# Altium schematic sheet Sheet1.SchDoc(Sheet1)
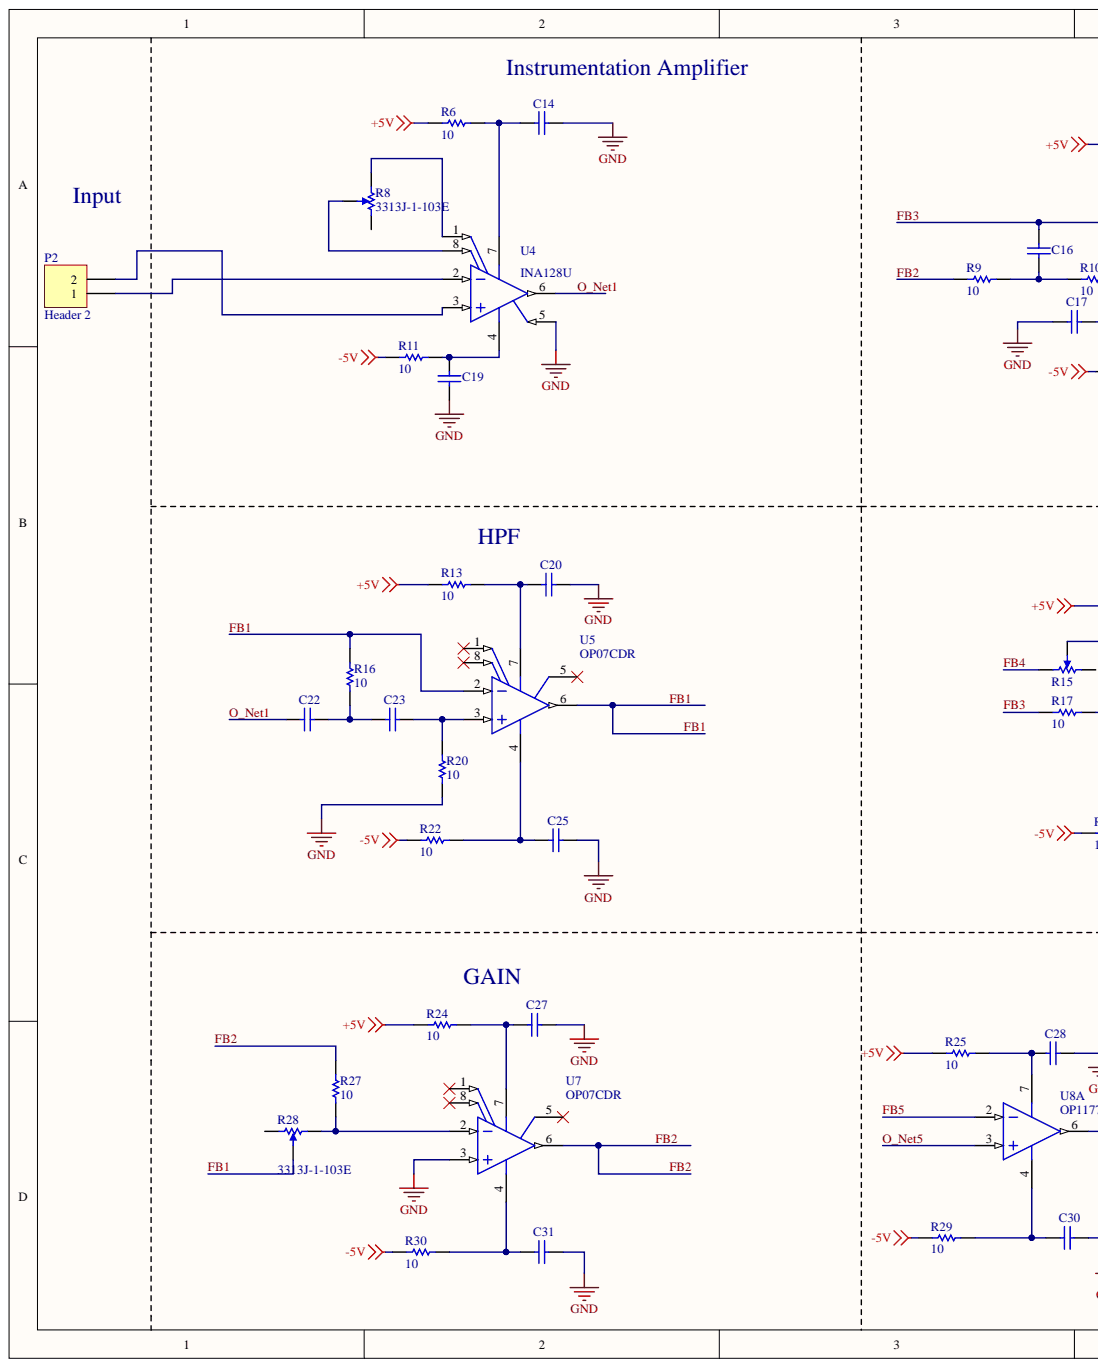
[diagram p0: Sheet1.SchDoc, left]
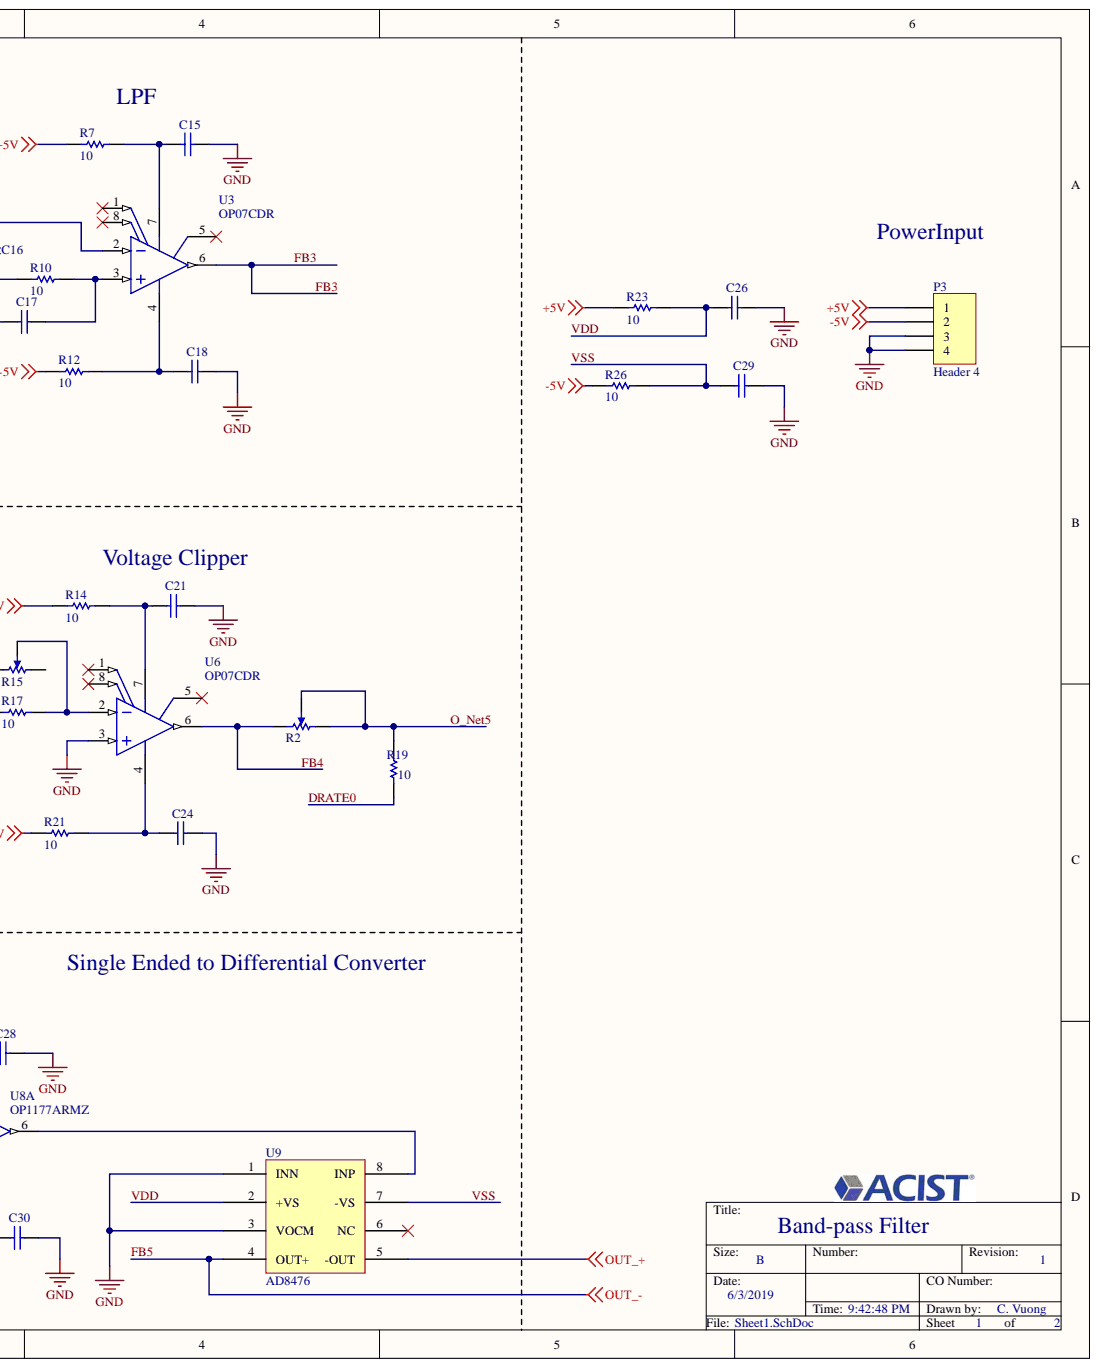
[diagram p1: Sheet1.SchDoc, right]

## Parts
C14  C1608X5R1A106K080AC  [CAPC1608X90X20ML10]  (value not drawn)
C15  C1608X5R1A106K080AC  [CAPC1608X90X20ML10]  (value not drawn)
C16  C1608X5R1A106K080AC  [CAPC1608X90X20ML10]  (value not drawn)
C17  C1608X5R1A106K080AC  [CAPC1608X90X20ML10]  (value not drawn)
C18  C1608X5R1A106K080AC  [CAPC1608X90X20ML10]  (value not drawn)
C19  C1608X5R1A106K080AC  [CAPC1608X90X20ML10]  (value not drawn)
C20  C1608X5R1A106K080AC  [CAPC1608X90X20ML10]  (value not drawn)
C21  C1608X5R1A106K080AC  [CAPC1608X90X20ML10]  (value not drawn)
C22  C1608X5R1A106K080AC  [CAPC1608X90X20ML10]  (value not drawn)
C23  C1608X5R1A106K080AC  [CAPC1608X90X20ML10]  (value not drawn)
C24  C1608X5R1A106K080AC  [CAPC1608X90X20ML10]  (value not drawn)
C25  C1608X5R1A106K080AC  [CAPC1608X90X20ML10]  (value not drawn)
C26  C1608X5R1A106K080AC  [CAPC1608X90X20ML10]  (value not drawn)
C27  C1608X5R1A106K080AC  [CAPC1608X90X20ML10]  (value not drawn)
C28  C1608X5R1A106K080AC  [CAPC1608X90X20ML10]  (value not drawn)
C29  C1608X5R1A106K080AC  [CAPC1608X90X20ML10]  (value not drawn)
C30  C1608X5R1A106K080AC  [CAPC1608X90X20ML10]  (value not drawn)
C31  C1608X5R1A106K080AC  [CAPC1608X90X20ML10]  (value not drawn)
P2  Header 2  [HDR1X2]
P3  Header 4  [HDR1X4]
R2  3313J-1-103E  [BOUR-3313J-1_V]
R6  10  [RESC1608X50X30LL20T20]
R7  10  [RESC1608X50X30LL20T20]
R8  3313J-1-103E  [BOUR-3313J-1_V]
R9  10  [RESC1608X50X30LL20T20]
R10  10  [RESC1608X50X30LL20T20]
R11  10  [RESC1608X50X30LL20T20]
R12  10  [RESC1608X50X30LL20T20]
R13  10  [RESC1608X50X30LL20T20]
R14  10  [RESC1608X50X30LL20T20]
R15  3313J-1-103E  [BOUR-3313J-1_V]
R16  10  [RESC1608X50X30LL20T20]
R17  10  [RESC1608X50X30LL20T20]
R19  10  [RESC1608X50X30LL20T20]
R20  10  [RESC1608X50X30LL20T20]
R21  10  [RESC1608X50X30LL20T20]
R22  10  [RESC1608X50X30LL20T20]
R23  10  [RESC1608X50X30LL20T20]
R24  10  [RESC1608X50X30LL20T20]
R25  10  [RESC1608X50X30LL20T20]
R26  10  [RESC1608X50X30LL20T20]
R27  10  [RESC1608X50X30LL20T20]
R28  3313J-1-103E  [BOUR-3313J-1_V]
R29  10  [RESC1608X50X30LL20T20]
R30  10  [RESC1608X50X30LL20T20]
U3  OP07CDR  [D0008A_M]
U4  INA128U  [D0008A_N]
U5  OP07CDR  [D0008A_M]
U6  OP07CDR  [D0008A_M]
U7  OP07CDR  [D0008A_M]
U8  OP1177ARMZ  [ADI-RM-8_M]  (part A)
U9  AD8476  [MSOP8]

## Nets
+5V: P3.1 R6.2 R7.2 R13.2 R14.2 R23.2 R24.2 R25.2
-5V: P3.2 R11.2 R12.2 R21.2 R22.2 R26.2 R29.2 R30.2
GND: C14.1 C15.1 C17.1 C18.1 C19.1 C20.1 C21.1 C24.1 C25.1 C26.1 C27.1 C28.1 C29.1 C30.1 C31.1 P3.3 P3.4 R20.2 U4.5 U6.3 U7.3 U9.1(INN) U9.3(VOCM)
OUT_+: U9.5(-OUT)
FB1: R16.1 R28.2 U5.2 U5.6
FB2: R9.2 R27.1 U7.6
FB3: C16.1 R17.2 U3.2 U3.6
FB4: R2.3 R15.3 U6.6
FB5: U8.2 U9.4(OUT+)
O_Net1: C22.2 U4.6
O_Net5: R2.1 R2.2 R19.1 U8.3
VDD: C26.2 R23.1 U9.2(+VS)
VDD_D: R19.2
VSS: C29.2 R26.1 U9.7(-VS)
NetC14_2: C14.2 R6.1 U4.7
NetC15_2: C15.2 R7.1 U3.7
NetC16_2: C16.2 R9.1 R10.2
NetC17_2: C17.2 R10.1 U3.3
NetC18_2: C18.2 R12.1 U3.4
NetC19_2: C19.2 R11.1 U4.4
NetC20_2: C20.2 R13.1 U5.7
NetC21_2: C21.2 R14.1 U6.7
NetC22_1: C22.1 C23.2 R16.2
NetC23_1: C23.1 R20.1 U5.3
NetC24_2: C24.2 R21.1 U6.4
NetC25_2: C25.2 R22.1 U5.4
NetC27_2: C27.2 R24.1 U7.7
NetC28_2: C28.2 R25.1 U8.7
NetC30_2: C30.2 R29.1 U8.4
NetC31_2: C31.2 R30.1 U7.4
NetP2_1: P2.1 U4.2
NetP2_2: P2.2 U4.3
NetR8_1: R8.1 U4.1
NetR8_2: R8.2 U4.8
NetR15_2: R15.2 R17.1 U6.2
NetR27_2: R27.2 R28.3 U7.2
NetU8_6: U8.6 U9.8(INP)

Not connected: R8 3; R15 1; R28 1; U3 1 5 8; U5 1 5 8; U6 1 5 8; U7 1 5 8; U9 6(NC)

## Library data of the parts
C14-C31 = CMP-2000-04979-1: Chip Capacitor, 10 uF, +/- 10%, 10 V, -55 to 85 degC, 0603 (1608 Metric), RoHS, Tape and Reel; PCN Part Number: MLCCPartNumberChange30/Nov/2012; Manufacturer: TDK; Voltage - Rated: 10V; Temperature Coefficient: X5R; Product Training Modules: CSeriesGeneralApplicationsSEAT,CCV,andTVCLDesignToolsGuidetoReplacinganElectrolyticCapacitorwithanMLCC; Other Names: 445-7486-1; Online Catalog: CSeries,GeneralPurpose; Kits: 445-9003-KIT-ND-KITCAPCERHICAPMLCCSMD; Design Resources: 20WNon-IsolatedBuckLEDDriverUsingPLLNK460KG; Capacitance: 10µF; Tolerance: ±10%; Thickness (Max): 0.035"(0.90mm); Standard Package: 1; Size / Dimension: 0.063"Lx0.031"W(1.60mmx0.80mm); Series: C; RoHS Information: RoHSCertificate-MLCC; Ratings: -; Packaging: CutTape(CT); Package Reference: 603; Package Description: 2-Pin Surface Mount Device, Body 1.6 x 0.8 mm; Package / Case: 0603(1608Metric); Operating Temperature: -55degC~85degC; Mounting Type: SurfaceMount,MLCC; Manufacturer URL: http://www.tdk.com/; Library Name: Altium Content Vault; Lead Style: -; Lead Spacing: -; Height - Seated (Max): -; Features: -; Family: CeramicCapacitors; Datasheet Version: Mar-15; Datasheet URL: http://download.siliconexpert.com/pdfs/2015/4/21/15/54/45/779/tdk_/manual/37024850441143360mlcc_commercial_general_en.pdf; Component Kind: Standard; Category: Capacitors; Applications: GeneralPurpose
P2 = Header 2: Header, 2-Pin; Signal Integrity: Connector; Library Name: Miscellaneous Connectors.IntLib; LatestRevisionNote: Re-released for DXP Platform.; LatestRevisionDate: 17-Jul-2002; Component Kind: Standard; Publisher: Altium Limited
P3 = Header 4: Header, 4-Pin; Signal Integrity: Connector; Library Name: Miscellaneous Connectors.IntLib; LatestRevisionNote: Re-released for DXP Platform.; LatestRevisionDate: 17-Jul-2002; Component Kind: Standard; Publisher: Altium Limited
R2 R8 R15 R28 = CMP-2000-05585-1: Trimming Potentiometer, 10 KOhm, -55 to 125 degC, 3-Pin SMD, RoHS, Tape and Reel; Manufacturer: Bourns; Track Resistance: 10kohm; Temperature Coefficient: ±100ppm/°C; SVHC: NoSVHC(16-Jun-2014); Resistance Tolerance: ±20%; Power Rating: 125mW; No. of Turns: 1; RoHS Compliant: Yes; Potentiometer Mounting: SMD; Package Reference: 3313J-1; Package Description: 3-Pin Surface Mount Device, Body 3.5 x 3.2 mm, Pitch 1.15 mm; Mounting Technology: SurfaceMount; Manufacturer URL: http://www.bourns.com/; Library Name: Altium Content Vault; Datasheet Version: 05/2016; Datasheet URL: http://www.bourns.com/docs/Product-Datasheets/3313.pdf; Component Kind: Standard
R6 R7 R9-R14 R16 R17 R19-R27 R29 R30 = CMP-2000-02559-1: Part Number: RR0816P-241-D; Manufacturer: Susumu; Library Name: Altium Content Vault; Component Kind: Standard
U3 U5-U7 = CMP-0015-00070-2: Low-Offset Voltage Operational Amplifier, 6 to 36 V, 0 to 70 degC, 8-pin SOIC (D8), Green (RoHS & no Sb/Br); Manufacturer: Texas Instruments; Vn at 1kHz(Typ)(nV/rtHz): 9.8; Vio (25C)(Max)(mV): 0.15; Total Supply Voltage(Min)(+5V is 5, +/-5V is 10): 6; Total Supply Voltage(Max)(+5V is 5, +/-5V is 10): 36; Sub Family: General Purpose Amplifier, High Supply Voltage Amplifier (>=30V), Low Noise Amp (<=10nV/rtHz), Low Offset Voltage Amplifier (<=500uV); Slew Rate(Typ)(V/us): 0.3; Rating: Catalog; Offset Drift(Typ)(uV/C): 0.5; Number of Channels: 1; Iq per channel(Max)(mA): 5; IIB(Max)(pA): 7000; GBW(Typ)(MHz): 0.6; CMRR(Min)(dB): 100; PartNumber: OP07CDR; PackageVersion: 4040047-3/M, 06/2011; PackageReference: D0008A; PackageDescription: 8-Pin Plastic Small Outline, Body 4.9 x 3.9 mm, Pitch 1.27 mm; Mounting Technology: Surface Mount; Library Name: Altium Content Vault; Eco-Plan: Green (RoHS & no Sb/Br); DatasheetVersion: SLOS099E; ComponentLink3URL: http://www.ti.com/litv/pdf/msoi002j; ComponentLink3Description: Package Specification; ComponentLink2URL: http://www.ti.com/lit/ds/symlink/op07c.pdf; ComponentLink2Description: Datasheet; ComponentLink1URL: http://www.ti.com/; ComponentLink1Description: Manufacturer URL; Component Kind: Standard; Code_JEDEC: MS-012
U4 = CMP-0992-00017-2: Precision, Low Power Instrumentation Amplifier, -40 to 85 degC, 8-pin SOIC (D8), Green (RoHS & no Sb/Br); Manufacturer: Texas Instruments; Vs(Min)(V): 4.5; Vs(Max)(V): 36; SubFamily: Dual Supply; Quiescent Current (+/-)(Typ)(mA): 0.7; Number of Channels: 1; Non-Linearity (+/-)(Max)(%): 0.002; Noise at 1kHz(Typ)(nV/rt(Hz)): 8; Input Offset Drift (+/-)(Max)(uV/Degrees Celsius): 0.5; Input Offset (+/-)(Max)(uV): 50; Input Bias Current (+/-)(Max)(nA): 5; Gain(V/V): 1 to 10000; CMRR(Min)(dB): 120; PartNumber: INA128U; PackageVersion: 4040047-3/M, 06/2011; PackageReference: D0008A; PackageDescription: 8-Pin Plastic Small Outline, Body 4.9 x 3.9 mm, Pitch 1.27 mm; Mounting Technology: Surface Mount; Library Name: Altium Content Vault; Eco-Plan: Green (RoHS & no Sb/Br); DatasheetVersion: SBOS051B; ComponentLink3URL: http://www.ti.com/litv/pdf/msoi002j; ComponentLink3Description: Package Specification; ComponentLink2URL: http://www.ti.com/general/docs/lit/getliterature.tsp?genericPartNumber=INA128&fileType=pdf; ComponentLink2Description: Datasheet; ComponentLink1URL: http://www.ti.com/; ComponentLink1Description: Manufacturer URL; Component Kind: Standard; Code_JEDEC: MS-012
U8 = ADI-AD_ADA_OPXXXX-X-8: Single Channel Wide Bandwidth Operational Amplifier, 1.3 MHz BW, 700 mV/us SR, Industrial, 8-pin SOP (RM-8), Tube; Manufacturer: Analog Devices; Generic Part Number: OP1177; V Span Min: 5V; V Span Max: 36V; Small Signal Bandwidth: 1.3MHz; Iq/Amp: 500uA; Input Offset Voltage: 15uV; Input Bias Current: 500pA; Amplifiers Per Package: 1; Slew Rate: 700mV/us; RevisionNote: Reference Circuit URL fixed; PartNumber: OP1177ARMZ; PackageReference: RM-8; PackageDescription: 8-Pin Mini Small Outline Package, Body 3 x 3 mm, Pitch 0.65 mm; Mounting Technology: Surface Mount; Library Name: Project X.SCHLIB; DatasheetVersion: Rev. G; ComponentLink4URL: http://www.analog.com/en/all-operational-amplifiers-op-amps/operational-amplifiers-op-amps/op1177/products/product.html#product-recommendations; ComponentLink4Description: Reference Circuit; ComponentLink3URL: http://www.analog.com/static/imported-files/packages/PKG_PDF/MSOP%28RM%29/RM_8.pdf; ComponentLink3Description: Package Specification; ComponentLink2URL: http://www.analog.com/static/imported-files/data_sheets/OP1177_2177_4177.pdf; ComponentLink2Description: Datasheet; ComponentLink1URL: http://www.analog.com/en/index.html; ComponentLink1Description: Manufacturer URL; Component Kind: Standard; Code_JEDEC: MO-187-AA
U9 = AD8476: Library Name: Project X.SCHLIB; Component Kind: Standard
